# Altium schematic sheet SCH - Inputs and Intermediary.SchDoc
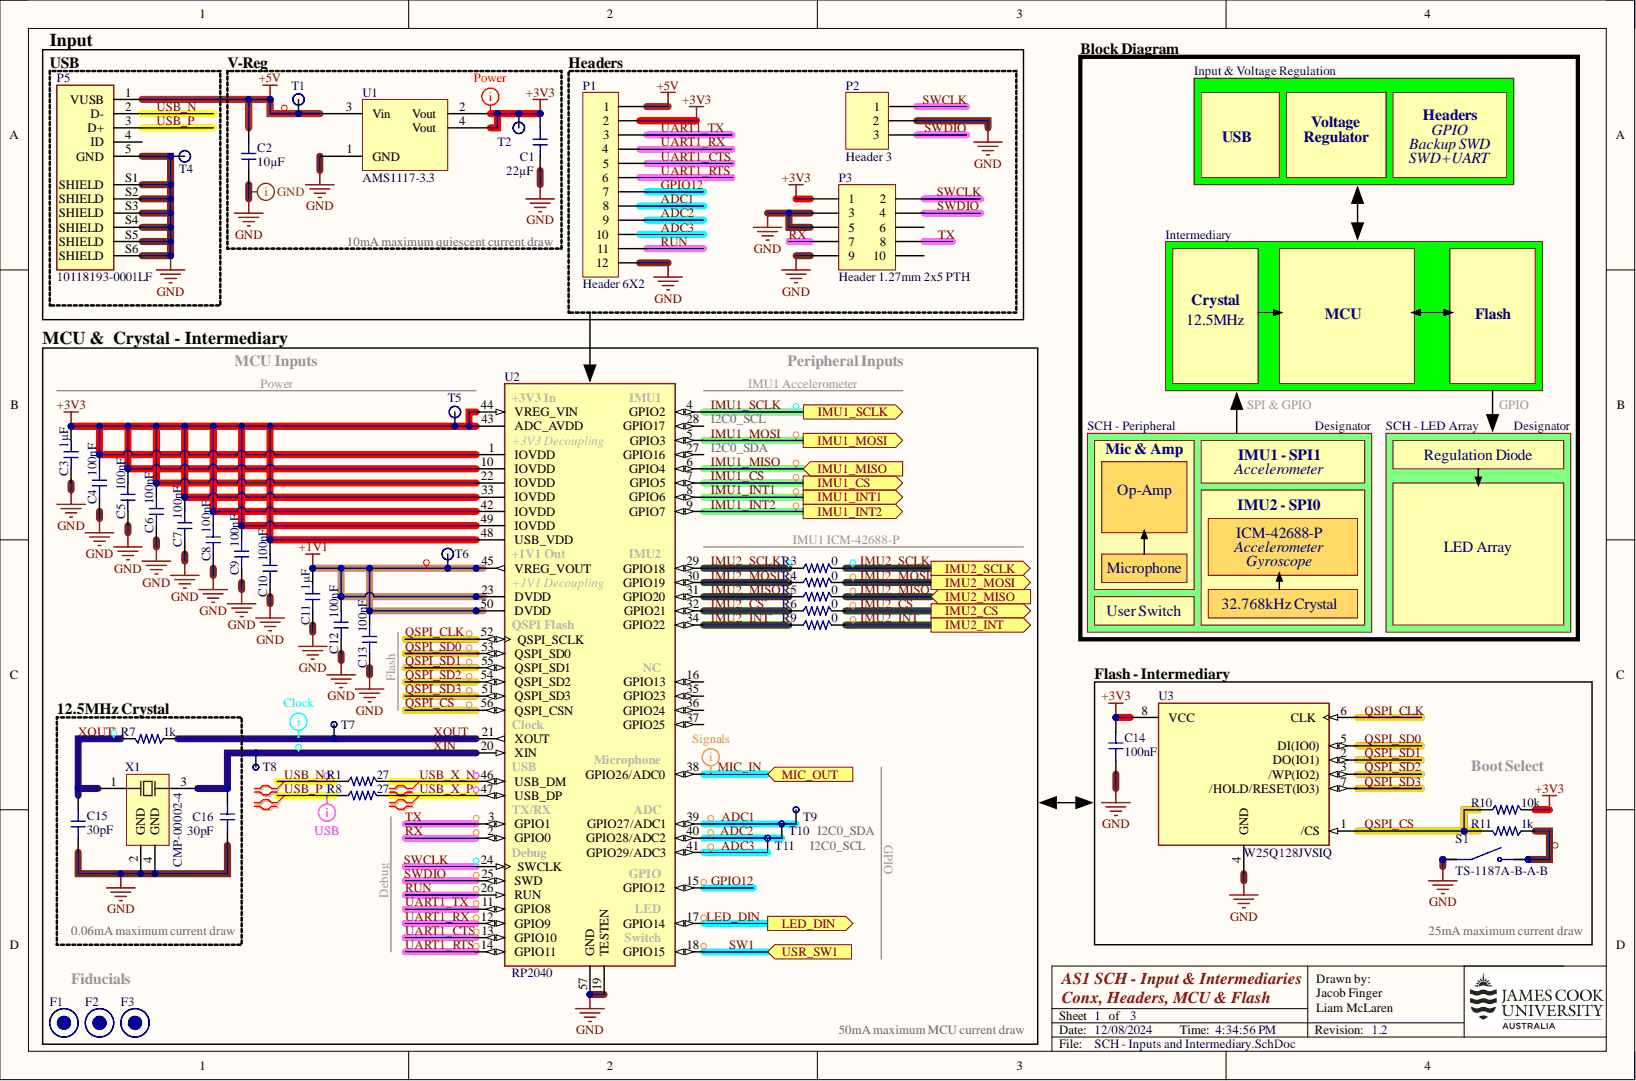
[diagram p0: SCH - Inputs and Intermediary.SchDoc]

## Parts
C1  value 22µF  [CAP-0603]  (hidden comment: CL10A226MQ8NRNC)
C2  value 10µF  [CAP-0402]  (hidden comment: CL05A106MQ5NUNC)
C3  value 1µF  [CAP-0402]  (hidden comment: CL05A105KA5NQNC)
C4  value 100nF  [CAP-0402]  (hidden comment: CL05B104KB54PNC)
C5  value 100nF  [CAP-0402]  (hidden comment: CL05B104KB54PNC)
C6  value 100nF  [CAP-0402]  (hidden comment: CL05B104KB54PNC)
C7  value 100nF  [CAP-0402]  (hidden comment: CL05B104KB54PNC)
C8  value 100nF  [CAP-0402]  (hidden comment: CL05B104KB54PNC)
C9  value 100nF  [CAP-0402]  (hidden comment: CL05B104KB54PNC)
C10  value 100nF  [CAP-0402]  (hidden comment: CL05B104KB54PNC)
C11  value 1µF  [CAP-0402]  (hidden comment: CL05A105KA5NQNC)
C12  value 100nF  [CAP-0402]  (hidden comment: CL05B104KB54PNC)
C13  value 100nF  [CAP-0402]  (hidden comment: CL05B104KB54PNC)
C14  value 100nF  [CAP-0402]  (hidden comment: CL05B104KB54PNC)
C15  value 30pF  [CAP-0603]  (hidden comment: 0603CG300J500NT)
C16  value 30pF  [CAP-0603]  (hidden comment: 0603CG300J500NT)
F1  Fiducial Pad  [Fiducial]  (value not drawn)  (no BOM)
F2  Fiducial Pad  [Fiducial]  (value not drawn)  (no BOM)
F3  Fiducial Pad  [Fiducial]  (value not drawn)  (no BOM)
P1  Header 6X2  [HDR2X6]  (no BOM)
P2  Header 3  [HDR1X3]  (no BOM)
P3  Header 1.27mm 2x5 PTH  [Header 1.27mm 2x5 PTH]  (no BOM)
P5  10118193-0001LF  [FCI_10118193-0001LF]
R1  value 27  [RES-0603]  (hidden comment: 0603WAF270JT5E)
R3  value 0  [RES-0402]  (hidden comment: 0402WGF0000TCE)
R4  value 0  [RES-0402]  (hidden comment: 0402WGF0000TCE)
R5  value 0  [RES-0402]  (hidden comment: 0402WGF0000TCE)
R6  value 0  [RES-0402]  (hidden comment: 0402WGF0000TCE)
R7  value 1k  [RES-0402]  (hidden comment: 0402WGF1001TCE)
R8  value 27  [RES-0603]  (hidden comment: 0603WAF270JT5E)
R9  value 0  [RES-0402]  (hidden comment: 0402WGF0000TCE)
R10  value 10k  [RES-0402]  (hidden comment: 0402WGF1002TCE)
R11  value 1k  [RES-0402]  (hidden comment: 0402WGF1001TCE)
S1  TS-1187A-B-A-B  [SW_TS-1187A-B-A-B]
T1  TP  [TestPoint]  (value not drawn)  (no BOM)
T2  TP  [TestPoint]  (value not drawn)  (no BOM)
T4  TP  [TestPoint]  (value not drawn)  (no BOM)
T5  TP  [TestPoint]  (value not drawn)  (no BOM)
T6  TP  [TestPoint]  (value not drawn)  (no BOM)
T7  TP  [TestPoint - Small]  (value not drawn)  (no BOM)
T8  TP  [TestPoint - Small]  (value not drawn)  (no BOM)
T9  TP  [TestPoint - Small]  (value not drawn)  (no BOM)
T10  TP  [TestPoint - Small]  (value not drawn)  (no BOM)
T11  TP  [TestPoint - Small]  (value not drawn)  (no BOM)
U1  AMS1117-3.3  [SOT230P700X180-4N]
U2  RP2040  [QFN40P700X700X90-57N]
U3  W25Q128JVSIQ  [SOIC127P790X216-8N]
X1  CMP-00002-4  [X322512MSB4SI-Footprint-1]

## Ports
IMU1_CS, IMU1_INT1, IMU1_INT2, IMU1_MISO, IMU1_MOSI, IMU1_SCLK, IMU2_CS, IMU2_INT, IMU2_MISO, IMU2_MOSI, IMU2_SCLK, LED_DIN, MIC_OUT, USR_SW1

## Nets
+1V1: C11.1 C12.2 C13.2 T6.1 U2.23(DVDD) U2.45(VREG_VOUT) U2.50(DVDD)
+3V3: C1.2 C3.2 C4.2 C5.2 C6.2 C7.2 C8.2 C9.2 C10.2 C14.2 P1.2 P3.1 R10.2 T2.1 T5.1 U1.2(Vout) U1.4(Vout) U2.1(IOVDD) U2.10(IOVDD) U2.22(IOVDD) U2.33(IOVDD) U2.42(IOVDD) U2.43(ADC_AVDD) U2.44(VREG_VIN) U2.48(USB_VDD) U2.49(IOVDD) U3.8(VCC)
+5V: C2.2 P1.1 P5.1(VUSB) T1.1 U1.3(Vin)
GND: C1.1 C2.1 C3.1 C4.1 C5.1 C6.1 C7.1 C8.1 C9.1 C10.1 C11.2 C12.1 C13.1 C14.1 C15.1 C16.1 P1.12 P2.2 P3.3 P3.5 P3.9 P5.5(GND) P5.S1(SHIELD) P5.S2(SHIELD) P5.S3(SHIELD) P5.S4(SHIELD) P5.S5(SHIELD) P5.S6(SHIELD) S1.A S1.B T4.1 U1.1(GND) U2.19(TESTEN) U2.57(GND) U3.4(GND) X1.2(GND) X1.4(GND)
ADC1: P1.8 T9.1 U2.39(GPIO27/ADC1)
ADC2: P1.9 T10.1 U2.40(GPIO28/ADC2)
ADC3: P1.10 T11.1 U2.41(GPIO29/ADC3)
GPIO12: P1.7 U2.15(GPIO12)
IMU1_CS: U2.7(GPIO5) ; port IMU1_CS
IMU1_INT1: U2.8(GPIO6) ; port IMU1_INT1
IMU1_INT2: U2.9(GPIO7) ; port IMU1_INT2
IMU1_MISO: U2.6(GPIO4) ; port IMU1_MISO
IMU1_MOSI: U2.5(GPIO3) ; port IMU1_MOSI
IMU1_SCLK: U2.4(GPIO2) ; port IMU1_SCLK
IMU2_CS: R6.2 ; port IMU2_CS
IMU2_CS`: R6.1 U2.32(GPIO21)
IMU2_INT: R9.2 ; port IMU2_INT
IMU2_INT`: R9.1 U2.34(GPIO22)
IMU2_MISO: R5.2 ; port IMU2_MISO
IMU2_MISO`: R5.1 U2.31(GPIO20)
IMU2_MOSI: R4.2 ; port IMU2_MOSI
IMU2_MOSI`: R4.1 U2.30(GPIO19)
IMU2_SCLK: R3.2 ; port IMU2_SCLK
IMU2_SCLK`: R3.1 U2.29(GPIO18)
LED_DIN: U2.17(GPIO14) ; port LED_DIN
MIC_IN: U2.38(GPIO26/ADC0) ; port MIC_OUT
QSPI_CLK: U2.52(QSPI_SCLK) U3.6(CLK)
QSPI_CS: R10.1 R11.2 U2.56(QSPI_CSN) U3.1(/CS)
QSPI_SD0: U2.53(QSPI_SD0) U3.5(DI(IO0))
QSPI_SD1: U2.55(QSPI_SD1) U3.2(DO(IO1))
QSPI_SD2: U2.54(QSPI_SD2) U3.3(/WP(IO2))
QSPI_SD3: U2.51(QSPI_SD3) U3.7(/HOLD/RESET(IO3))
RUN: P1.11 U2.26(RUN)
RX: P3.7 U2.2(GPIO0)
SW1: U2.18(GPIO15) ; port USR_SW1
SWCLK: P2.1 P3.2 U2.24(SWCLK)
SWDIO: P2.3 P3.4 U2.25(SWD)
TX: P3.8 U2.3(GPIO1)
UART1_CTS: P1.5 U2.13(GPIO10)
UART1_RTS: P1.6 U2.14(GPIO11)
UART1_RX: P1.4 U2.12(GPIO9)
UART1_TX: P1.3 U2.11(GPIO8)
USB_N: P5.2(D-) R1.1
USB_P: P5.3(D+) R8.1
USB_X_N: R1.2 U2.46(USB_DM)
USB_X_P: R8.2 U2.47(USB_DP)
XIN: C16.2 T8.1 U2.20(XIN) X1.3
XOUT: R7.1 T7.1 U2.21(XOUT)
XOUT`: C15.2 R7.2 X1.1
NetR11_1: R11.1 S1.C S1.D

Not connected: P3 6 10; P5 4(ID); U2 16(GPIO13) 27(GPIO16) 28(GPIO17) 35(GPIO23) 36(GPIO24) 37(GPIO25)

## Library data of the parts
C1 = CMP-001-00157-2: Capacitor, Ceramic (MLCC), 22uF, ±20%, 6.3V, X5R, ±20%, SMD 0603; Manufacturer: Samsung Electro-Mechanics; Case/Package: 0603; Category: Capacitors - MLCC; Component Kind: Standard; ComponentLink1Description: Datasheet; ComponentLink1URL: https://datasheet.lcsc.com/lcsc/1811151138_Samsung-Electro-Mechanics-CL10A226MQ8NRNC_C59461.pdf; ComponentLink2Description: JLCPCB Part URL; ComponentLink2URL: https://jlcpcb.com/partdetail/C59461; ComponentLink3Description: LCSC Part URL; ComponentLink3URL: https://lcsc.com/product-detail/Multilayer-Ceramic-Capacitors-MLCC---SMD-SMT_Samsung-Electro-Mechanics-Samsung-Electro-Mechanics-CL10A226MQ8NRNC_C59461.html; Design Item ID: CL10A226MQ8NRNC; JLCPCB Basic Part: Yes; JLCPCB Part Number: C59461; Manufacturer Part Number: CL10A226MQ8NRNC; RoHS: Yes; Source: jlcpcb_capacitors_basic.schlib; Temperature Coefficient: X5R; Tolerance: 20%; Voltage Rating: 6.3V; Library Name: Finmac Systems
C2 = CMP-001-00141-3: Capacitor, Ceramic (MLCC), 10uF, ±20%, 6.3V, X5R, ±20%, SMD 0402; Manufacturer: Samsung Electro-Mechanics; Case/Package: 0402; Category: Capacitors - MLCC; Component Kind: Standard; ComponentLink1Description: Datasheet; ComponentLink1URL: https://datasheet.lcsc.com/lcsc/2208231630_Samsung-Electro-Mechanics-CL05A106MQ5NUNC_C15525.pdf; ComponentLink2Description: JLCPCB Part URL; ComponentLink2URL: https://jlcpcb.com/partdetail/C15525; ComponentLink3Description: LCSC Part URL; ComponentLink3URL: https://lcsc.com/product-detail/Multilayer-Ceramic-Capacitors-MLCC---SMD-SMT_Samsung-Electro-Mechanics-Samsung-Electro-Mechanics-CL05A106MQ5NUNC_C15525.html; Design Item ID: CL05A106MQ5NUNC; JLCPCB Basic Part: Yes; JLCPCB Part Number: C15525; Manufacturer Part Number: CL05A106MQ5NUNC; RoHS: Yes; Source: jlcpcb_capacitors_basic.schlib; Temperature Coefficient: X5R; Tolerance: 20%; Voltage Rating: 6.3V; Library Name: Finmac Systems
C3 C11 = CMP-001-00140-3: Capacitor, Ceramic (MLCC), 1uF, ±10%, 25V, X5R, ±10%, SMD 0402; Manufacturer: Samsung Electro-Mechanics; Case/Package: 0402; Category: Capacitors - MLCC; Component Kind: Standard; ComponentLink1Description: Datasheet; ComponentLink1URL: https://datasheet.lcsc.com/lcsc/1811091611_Samsung-Electro-Mechanics-CL05A105KA5NQNC_C52923.pdf; ComponentLink2Description: JLCPCB Part URL; ComponentLink2URL: https://jlcpcb.com/partdetail/C52923; ComponentLink3Description: LCSC Part URL; ComponentLink3URL: https://lcsc.com/product-detail/Multilayer-Ceramic-Capacitors-MLCC---SMD-SMT_Samsung-Electro-Mechanics-Samsung-Electro-Mechanics-CL05A105KA5NQNC_C52923.html; Design Item ID: CL05A105KA5NQNC; JLCPCB Basic Part: Yes; JLCPCB Part Number: C52923; Manufacturer Part Number: CL05A105KA5NQNC; RoHS: Yes; Source: jlcpcb_capacitors_basic.schlib; Temperature Coefficient: X5R; Tolerance: 10%; Voltage Rating: 25V; Library Name: Finmac Systems
C4-C10 C12-C14 = CMP-001-00146-3: Capacitor, Ceramic (MLCC), 100nF, ±10%, 50V, X7R, ±10%, SMD 0402; Manufacturer: Samsung Electro-Mechanics; Case/Package: 0402; Category: Capacitors - MLCC; Component Kind: Standard; ComponentLink1Description: Datasheet; ComponentLink1URL: https://datasheet.lcsc.com/lcsc/1810191222_Samsung-Electro-Mechanics-CL05B104KB54PNC_C307331.pdf; ComponentLink2Description: JLCPCB Part URL; ComponentLink2URL: https://jlcpcb.com/partdetail/C307331; ComponentLink3Description: LCSC Part URL; ComponentLink3URL: https://lcsc.com/product-detail/Multilayer-Ceramic-Capacitors-MLCC---SMD-SMT_Samsung-Electro-Mechanics-Samsung-Electro-Mechanics-CL05B104KB54PNC_C307331.html; Design Item ID: CL05B104KB54PNC; JLCPCB Basic Part: Yes; JLCPCB Part Number: C307331; Manufacturer Part Number: CL05B104KB54PNC; RoHS: Yes; Source: jlcpcb_capacitors_basic.schlib; Temperature Coefficient: X7R; Tolerance: 10%; Voltage Rating: 50V; Library Name: Finmac Systems
C15 C16 = CMP-001-00132-2: Capacitor, Ceramic (MLCC), 30pF, ±5%, 50V, C0G, ±5%, SMD 0603; Manufacturer: FH(Guangdong Fenghua Advanced Tech); Case/Package: 0603; Category: Capacitors - MLCC; Component Kind: Standard; ComponentLink1Description: Datasheet; ComponentLink1URL: https://datasheet.lcsc.com/lcsc/1810261611_FH--Guangdong-Fenghua-Advanced-Tech-0603CG300J500NT_C1658.pdf; ComponentLink2Description: JLCPCB Part URL; ComponentLink2URL: https://jlcpcb.com/partdetail/C1658; ComponentLink3Description: LCSC Part URL; ComponentLink3URL: https://lcsc.com/product-detail/Multilayer-Ceramic-Capacitors-MLCC---SMD-SMT_FH-Guangdong-Fenghua-Advanced-Tech-FH-Guangdong-Fenghua-Advanced-Tech-0603CG300J500NT_C1658.html; Design Item ID: 0603CG300J500NT; JLCPCB Basic Part: Yes; JLCPCB Part Number: C1658; Manufacturer Part Number: 0603CG300J500NT; RoHS: Yes; Source: jlcpcb_capacitors_basic.schlib; Temperature Coefficient: C0G; Tolerance: 5%; Voltage Rating: 50V; Library Name: Finmac Systems
F1-F3 = Fiducial: 1x2mm Fiducial Pad; ClassName: Fiducial; Component Class name: Fiducial; Library Name: DatumPenLibrary.SchLib
P1 = CMP-002-00136-1: Header, 6-Pin, Dual row; Component Type: Standard; Design Item ID: Header 6X2; LatestRevisionDate: 17-Jul-2002; LatestRevisionNote: Re-released for DXP Platform.; Pin Count: 12; Signal Integrity: Connector; Source: Miscellaneous Connectors.SchLib; Library Name: SCH_LIB_Assignment1.SchLib; Publisher: Altium Limited
P2 = CMP-002-00117-1: Header, 3-Pin; Component Type: Standard; Design Item ID: Header 3; LatestRevisionDate: 17-Jul-2002; LatestRevisionNote: Re-released for DXP Platform.; Pin Count: 3; Signal Integrity: Connector; Source: Miscellaneous Connectors.SchLib; Library Name: James Cook University; Publisher: Altium Limited
P3 = CMP-005-0002-2: Header 1.27mm pitch, 2x5, PTH through hole; Component Type: Standard; Design Item ID: Header 1.27mm 2x5 PTH; element14 Item: 1577743; Pins: 10; Library Name: SCH_LIB_Assignment1.SchLib; Source: JCULibrary.SchLib
P5 = CMP-045-000000-8: USB - micro B USB 2.0 Receptacle Connector 5 Position Surface Mount, Right Angle; Through Hole; MF: Amphenol ICC; Component Kind: Standard; Component Type: Standard; Design Item ID: 10118193-0001LF; JLCPCB Part: C132562; MP: 10118193-0001LF; Package: None; Pin Count: 11; SnapEDA_Link: https://www.snapeda.com/parts/10118193-0001LF/Amphenol%20FCI/view-part/2758989/?ref=snap; Source: SnapEDAsch.SchLib; Library Name: SCH_LIB_Assignment1.SchLib
R1 R8 = CMP-009-00289-2: Resistor, 27Ω, ±1%, 100mW, 75V, ±200ppm/℃, -55℃~+155℃, SMD 0603; Manufacturer: Uniroyal; Case/Package: 0603; Category: Resistors; Component Kind: Standard; ComponentLink1Description: Datasheet; ComponentLink1URL: https://datasheet.lcsc.com/lcsc/2205311845_UNI-ROYAL-Uniroyal-Elec-0603WAF270JT5E_C25190.pdf; ComponentLink2Description: JLCPCB Part URL; ComponentLink2URL: https://jlcpcb.com/partdetail/C25190; Design Item ID: 0603WAF270JT5E; JLCPCB Basic Part: Yes; JLCPCB Part Number: C25190; Manufacturer Part Number: 0603WAF270JT5E; Power Rating: 100mW; Source: jlcpcb_resistors_basic.schlib; Temperature Coefficient: ±200ppm/℃; Temperature Rating: -55℃~+155℃; Tolerance: 1%; Voltage Rating: 75V; Library Name: Finmac Systems
R3-R6 R9 = CMP-009-00178-4: Resistor, 0Ω, ±1%, 62.5mW, 50V, ±800ppm/℃, -55℃~+155℃, SMD 0402; Manufacturer: Uniroyal; Case/Package: 0402; Category: Resistors; Component Kind: Standard; ComponentLink1Description: Datasheet; ComponentLink1URL: https://datasheet.lcsc.com/lcsc/2206010216_UNI-ROYAL-Uniroyal-Elec-0402WGF0000TCE_C17168.pdf; ComponentLink2Description: JLCPCB Part URL; ComponentLink2URL: https://jlcpcb.com/partdetail/C17168; Design Item ID: 0402WGF0000TCE; JLCPCB Basic Part: Yes; JLCPCB Part Number: C17168; Manufacturer Part Number: 0402WGF0000TCE; Power Rating: 62.5mW; Source: jlcpcb_resistors_basic.schlib; Temperature Coefficient: ±800ppm/℃; Temperature Rating: -55℃~+155℃; Tolerance: 1%; Voltage Rating: 50V; Library Name: Finmac Systems
R7 R11 = CMP-009-00180-4: Resistor, 1kΩ, ±1%, 62.5mW, 50V, ±100ppm/℃, -55℃~+155℃, SMD 0402; Manufacturer: Uniroyal; Case/Package: 0402; Category: Resistors; Component Kind: Standard; ComponentLink1Description: Datasheet; ComponentLink1URL: https://datasheet.lcsc.com/lcsc/2206010216_UNI-ROYAL-Uniroyal-Elec-0402WGF1001TCE_C11702.pdf; ComponentLink2Description: JLCPCB Part URL; ComponentLink2URL: https://jlcpcb.com/partdetail/C11702; Design Item ID: 0402WGF1001TCE; JLCPCB Basic Part: Yes; JLCPCB Part Number: C11702; Manufacturer Part Number: 0402WGF1001TCE; Power Rating: 62.5mW; Source: jlcpcb_resistors_basic.schlib; Temperature Coefficient: ±100ppm/℃; Temperature Rating: -55℃~+155℃; Tolerance: 1%; Voltage Rating: 50V; Library Name: Finmac Systems
R10 = CMP-009-00181-4: Resistor, 10kΩ, ±1%, 62.5mW, 50V, ±100ppm/℃, -55℃~+155℃, SMD 0402; Manufacturer: Uniroyal; Case/Package: 0402; Category: Resistors; Component Kind: Standard; ComponentLink1Description: Datasheet; ComponentLink1URL: https://datasheet.lcsc.com/lcsc/2206010100_UNI-ROYAL-Uniroyal-Elec-0402WGF1002TCE_C25744.pdf; ComponentLink2Description: JLCPCB Part URL; ComponentLink2URL: https://jlcpcb.com/partdetail/C25744; Design Item ID: 0402WGF1002TCE; JLCPCB Basic Part: Yes; JLCPCB Part Number: C25744; Manufacturer Part Number: 0402WGF1002TCE; Power Rating: 62.5mW; Source: jlcpcb_resistors_basic.schlib; Temperature Coefficient: ±100ppm/℃; Temperature Rating: -55℃~+155℃; Tolerance: 1%; Voltage Rating: 50V; Library Name: Finmac Systems
S1 = CMP-015-000000-1: SPST 50mA @ 12VDC Top Actuated Round Button 160gf SMD Tactile Switches RoHS; Availability: Unavailable; Component Kind: Standard; Component Type: Standard; Design Item ID: TS-1187A-B-A-B; JLCPCB Part: C318884; MP: TS-1187A-B-A-B; Package: None; Pin Count: 4; Price: None; Source: TS-1187A-B-A-B.SchLib; Library Name: Finmac Systems
T1 T2 T4-T6 = TestPoint: Library Name: DatumPenLibrary.SchLib
T7-T11 = TestPoint Small: Library Name: DatumPenLibrary.SchLib
U1 = CMP-00001-4: 3.3V 1A LOW DROPOUT VOLTAGE REGULATOR SOT-223; Dropout voltage: 1.3 V; Component Kind: Standard; JLCPCB Part: C6186; Output current: 1 A; Output voltage: 3.3 V; Library Name: SCH_LIB_Assignment1.SchLib
U2 = CMP-043-000000-5: ARM Cortex-M0+ MCU 32-Bit Microcontroller for Raspberry Pi Pico; Availability: Available; Component Kind: Standard; Component Type: Standard; Design Item ID: RP2040; JLCPCB Part: C2040; MP: RP2040; Package: None; Pin Count: 57; Price: None; Source: RP2040.SchLib; Library Name: SCH_LIB_Assignment1.SchLib
U3 = CMP-031-00006-4: FLASH - NOR Memory IC 128Mb (16M x 8) SPI - Quad I/O, QPI, DTR 133 MHz 8-SOIC; MF: Winbond Electronics; Availability: In Stock; Check_prices: https://www.snapeda.com/parts/W25Q128JVSIQ/Winbond+Electronics/view-part/?ref=eda; Component Kind: Standard; Component Type: Standard; Design Item ID: W25Q128JVSIQ; JLCPCB Part: C97521; MP: W25Q128JVSIQ; Package: SOIC-8 Winbond; Pin Count: 8; Price: None; Purchase-URL: https://pricing.snapeda.com/search/part/W25Q128JVSIQ/?ref=eda; SnapEDA_Link: https://www.snapeda.com/parts/W25Q128JVSIQ/Winbond+Electronics/view-part/?ref=snap; Source: W25Q128JVSIQ.SchLib; Library Name: SCH_LIB_Assignment1.SchLib
X1 = CMP-003-00030-1: 12MHz SMD Crystal Resonator 20pF ±10ppm ±30ppm -40℃~+85℃ SMD3225-4P  Crystals ROHS Yangxing Tech; Max ESR: 80 Ω; Component Kind: Standard; Design Item ID: CMP-00002-4; Frequency: 12MHz; JLCPCB Part: C9002; Load Capacitance: 20pF; Library Name: Finmac Systems; Source: SCH_LIB_Assignment1.SchLib
